# Altium schematic sheet Sheet2.SchDoc
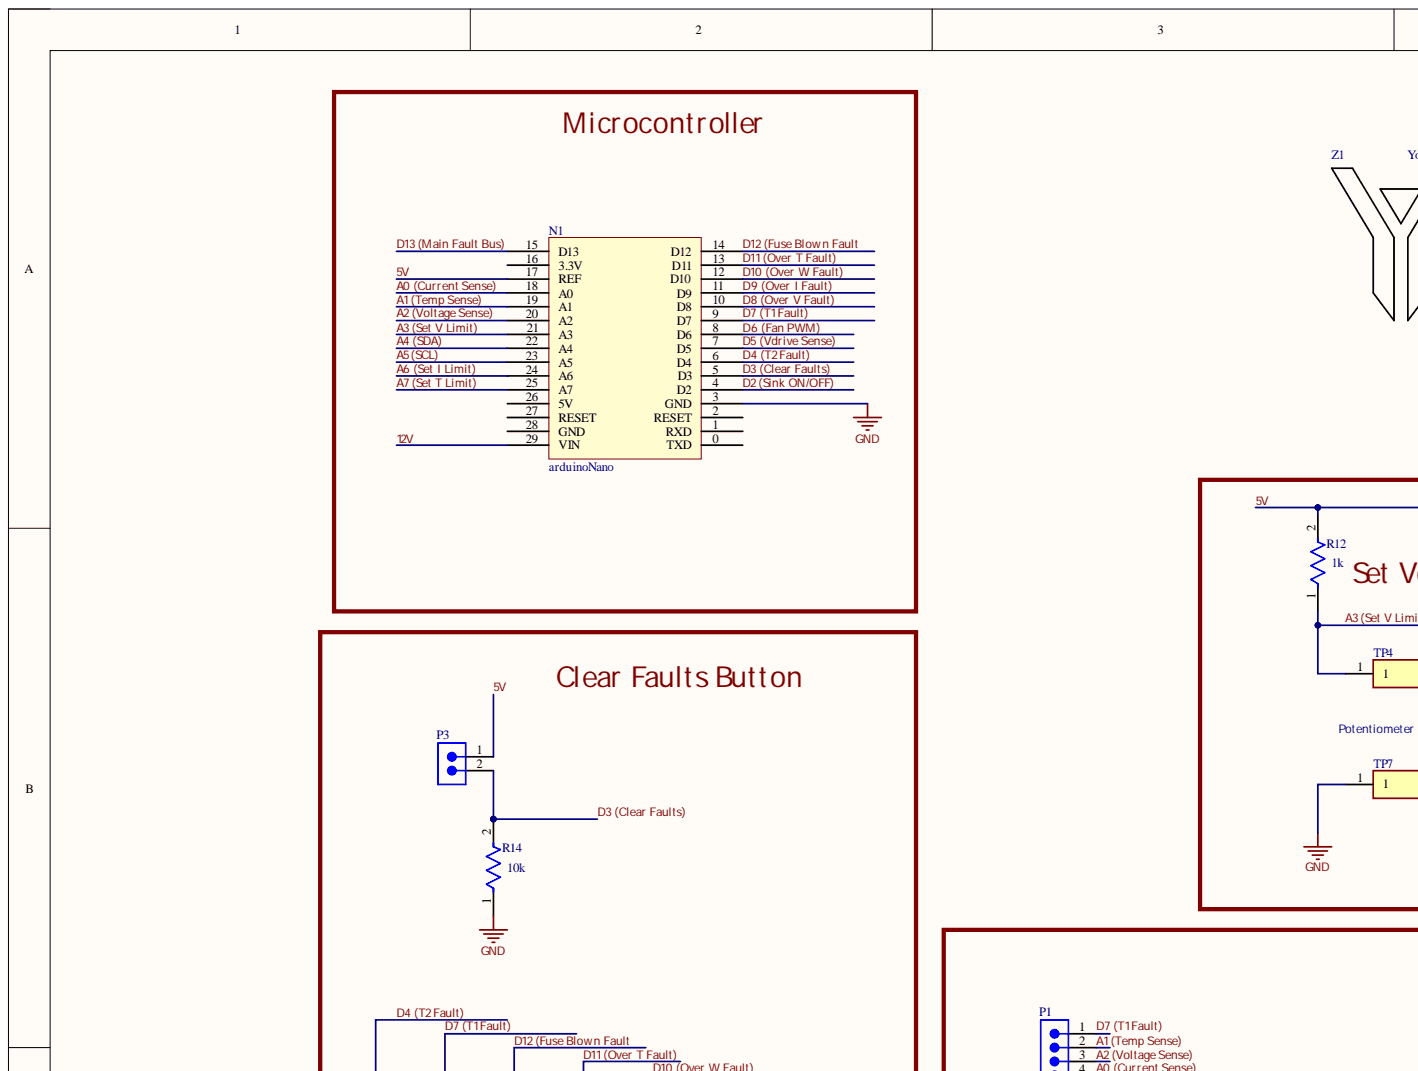
[diagram p0: Sheet2.SchDoc, top left]
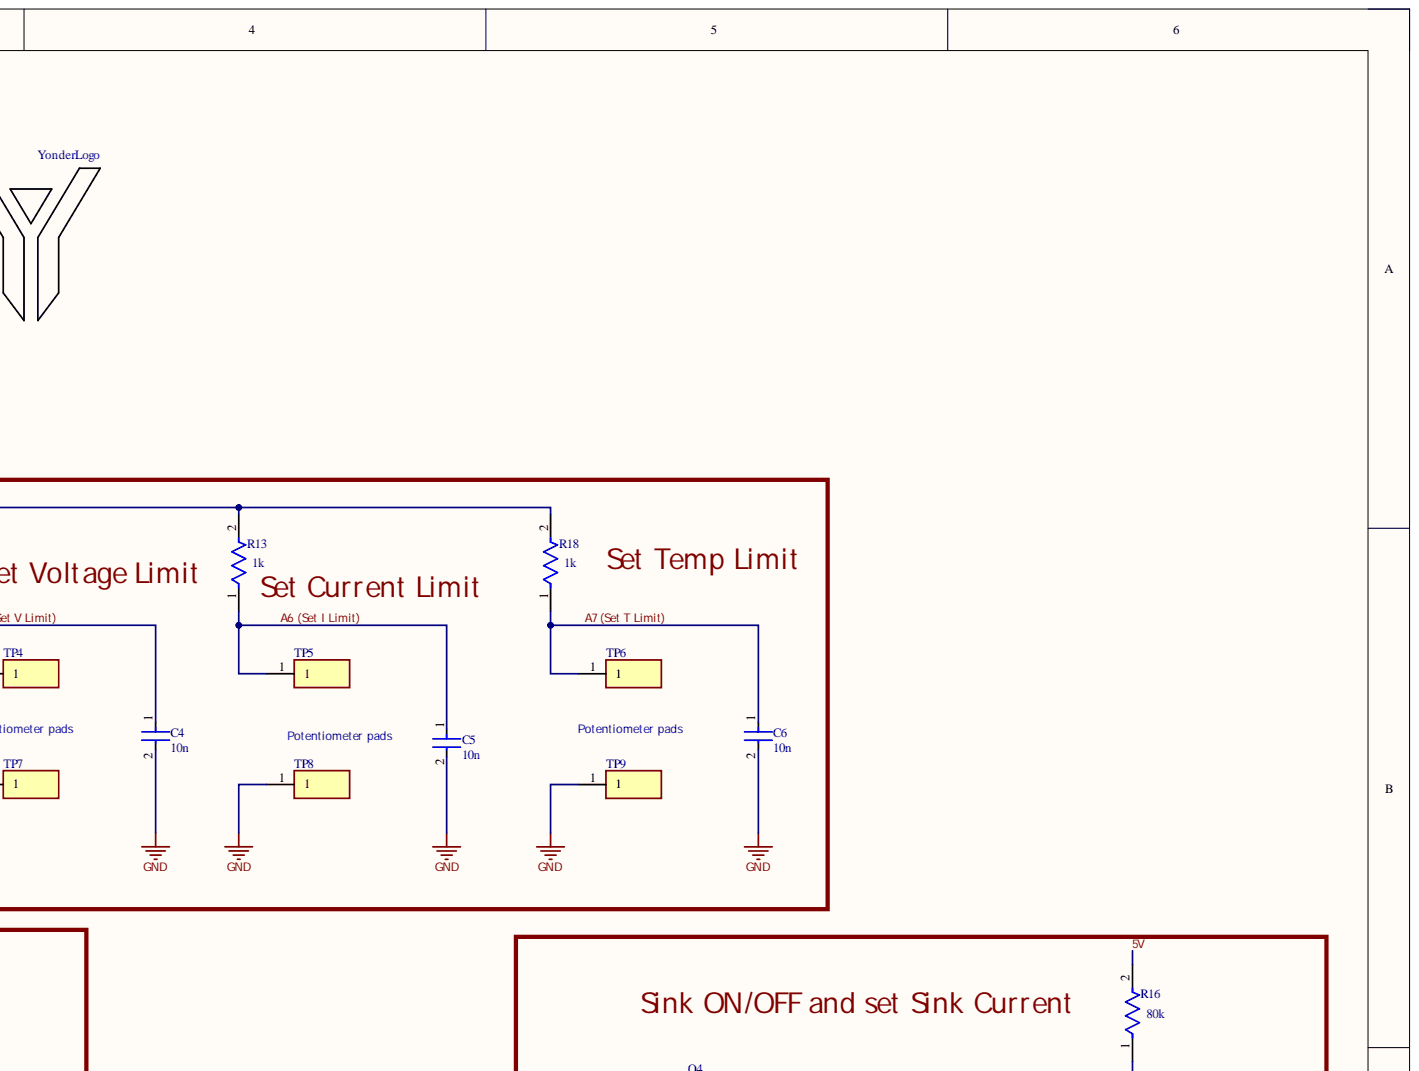
[diagram p1: Sheet2.SchDoc, top right]
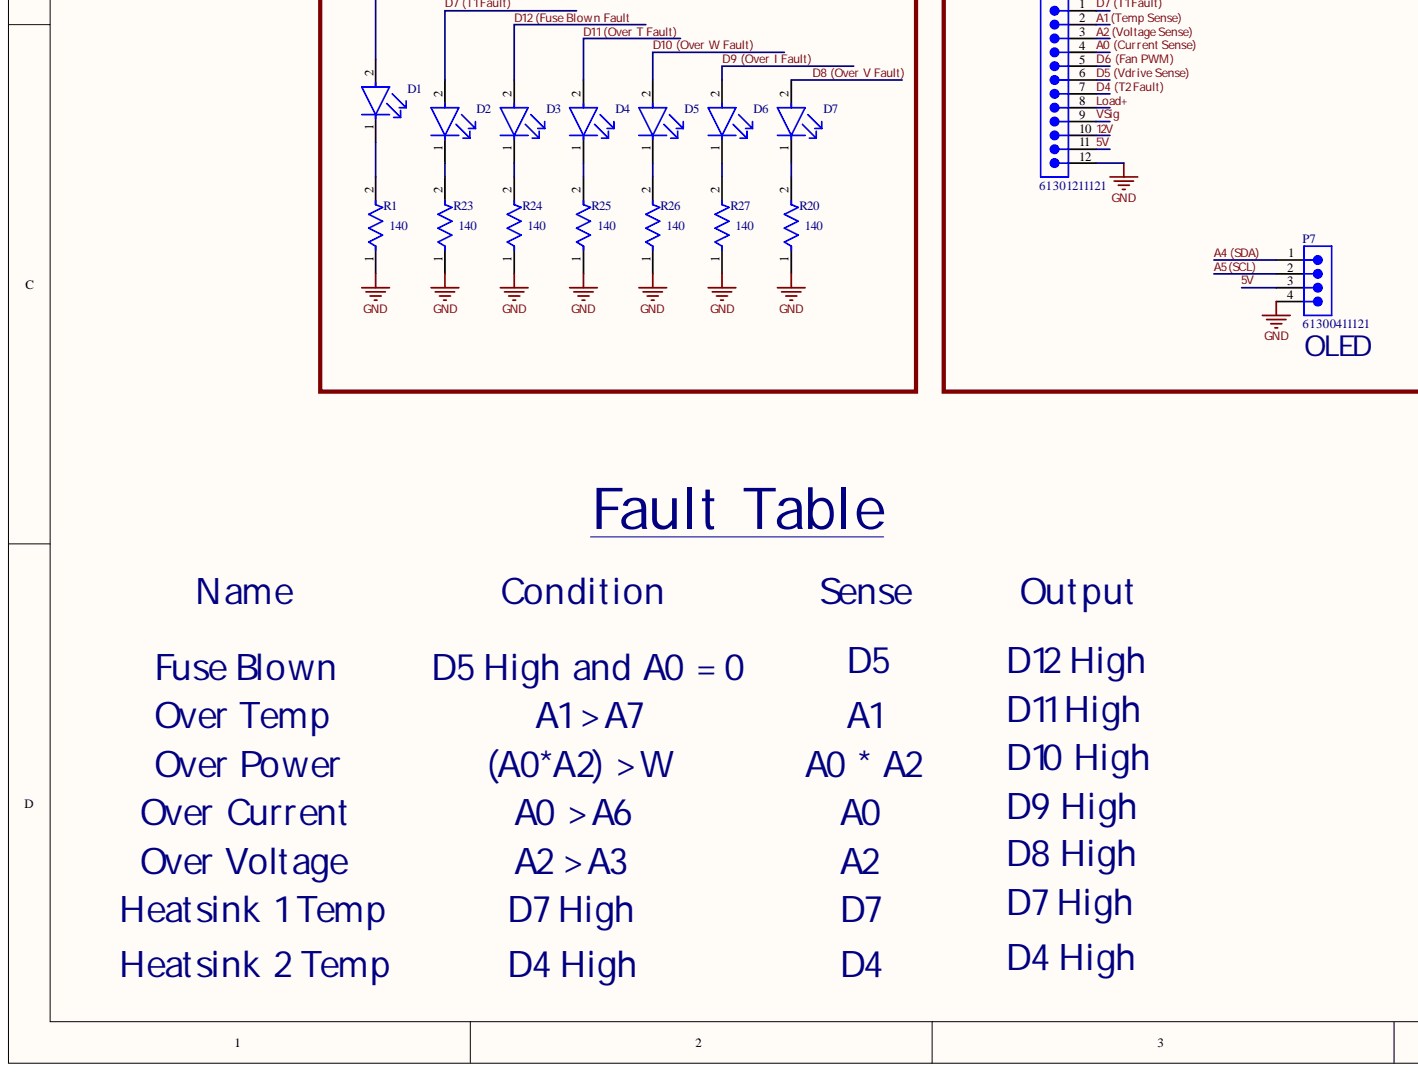
[diagram p2: Sheet2.SchDoc, bottom left]
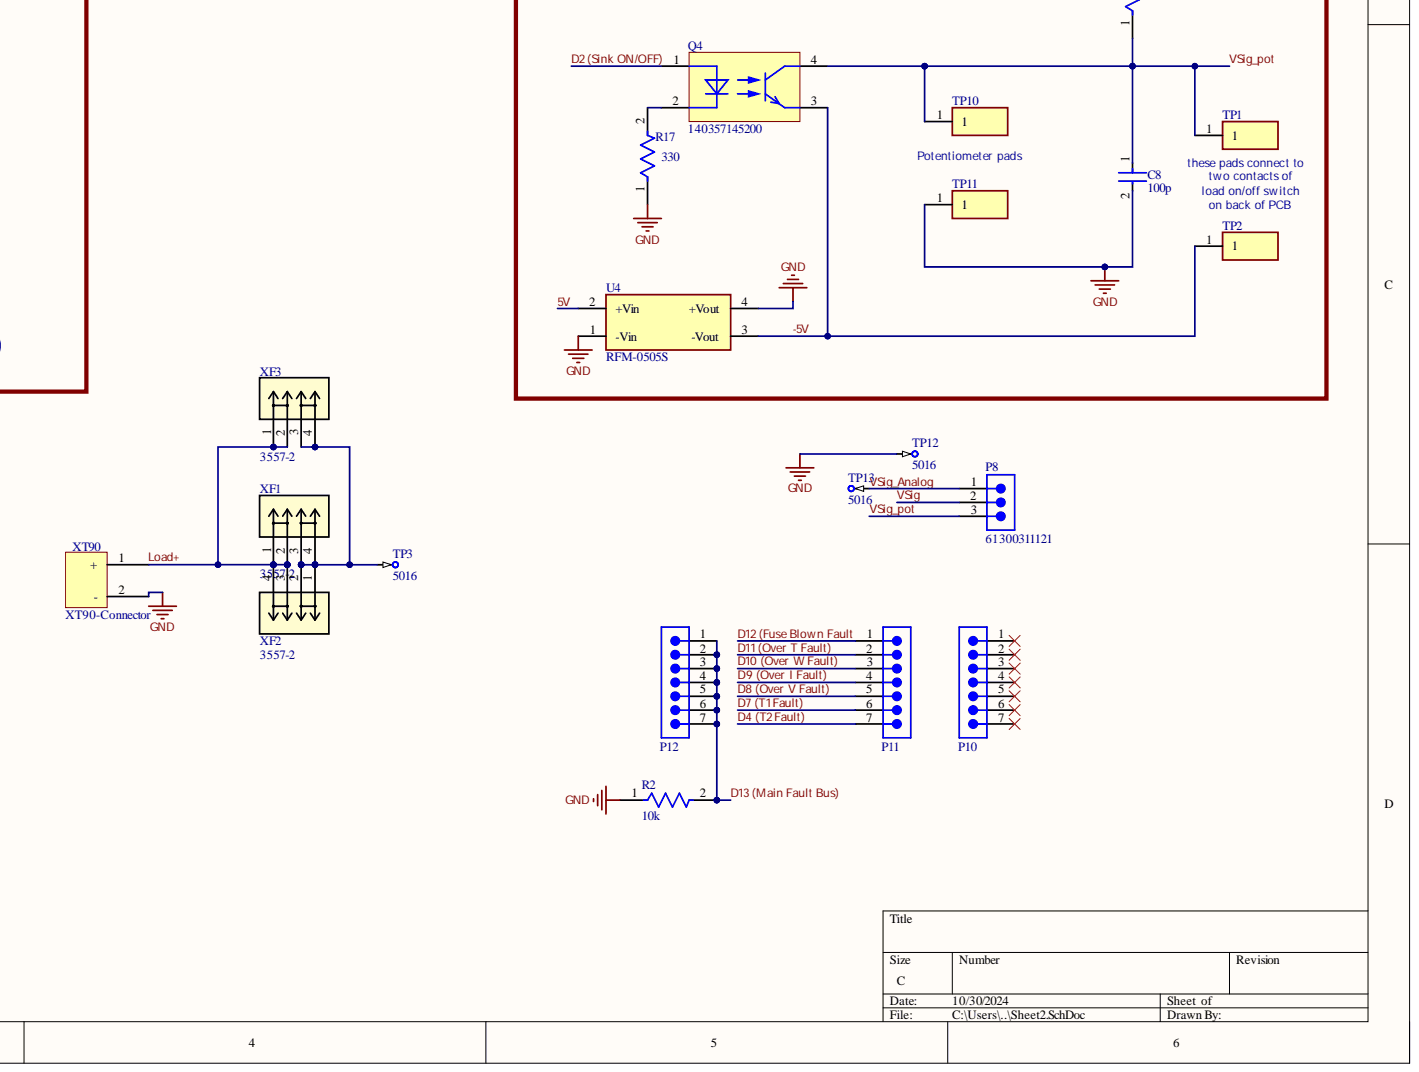
[diagram p3: Sheet2.SchDoc, bottom right]

## Parts
*XT1  XT90-Connector  [XT90-Footprint-1]
C4  10n  [FP-C1206C-EB-MFG]
C5  10n  [FP-C1206C-EB-MFG]
C6  10n  [FP-C1206C-EB-MFG]
C8  100p  [FP-C1206C-EB-MFG]
D1  LTST-C190EKT  [FP-LTST-C190EKT-MFG]  (value not drawn)
D2  LTST-C190EKT  [FP-LTST-C190EKT-MFG]  (value not drawn)
D3  LTST-C190EKT  [FP-LTST-C190EKT-MFG]  (value not drawn)
D4  LTST-C190EKT  [FP-LTST-C190EKT-MFG]  (value not drawn)
D5  LTST-C190EKT  [FP-LTST-C190EKT-MFG]  (value not drawn)
D6  LTST-C190EKT  [FP-LTST-C190EKT-MFG]  (value not drawn)
D7  LTST-C190EKT  [FP-LTST-C190EKT-MFG]  (value not drawn)
N1  arduinoNano  [Arduino nano]
P1  61301211121  [61301211121]
P3  61300211121  [61300211121]  (value not drawn)
P7  61300411121  [61300411121]
P8  61300311121  [61300311121]
P10  61300711121  [61300711121]  (value not drawn)
P11  61300711121  [61300711121]  (value not drawn)
P12  61300711121  [61300711121]  (value not drawn)
Q4  140357145200  [WL-OCPT_SOP4]
R1  140  [FP-RC1206-MFG]
R2  10k  [FP-RC1206-MFG]
R12  1k  [FP-RC1206-MFG]
R13  1k  [FP-RC1206-MFG]
R14  10k  [FP-RC1206-MFG]
R16  80k  [FP-RC1206-MFG]
R17  330  [FP-RC1206-MFG]
R18  1k  [FP-RC1206-MFG]
R20  140  [FP-RC1206-MFG]
R23  140  [FP-RC1206-MFG]
R24  140  [FP-RC1206-MFG]
R25  140  [FP-RC1206-MFG]
R26  140  [FP-RC1206-MFG]
R27  140  [FP-RC1206-MFG]
TP1  RCT-0C  [RCT-0C]  (value not drawn)
TP2  RCT-0C  [RCT-0C]  (value not drawn)
TP3  5016  [KEYSTONE-5016_V]
TP4  RCT-0C  [RCT-0C]  (value not drawn)
TP5  RCT-0C  [RCT-0C]  (value not drawn)
TP6  RCT-0C  [RCT-0C]  (value not drawn)
TP7  RCT-0C  [RCT-0C]  (value not drawn)
TP8  RCT-0C  [RCT-0C]  (value not drawn)
TP9  RCT-0C  [RCT-0C]  (value not drawn)
TP10  RCT-0C  [RCT-0C]  (value not drawn)
TP11  RCT-0C  [RCT-0C]  (value not drawn)
TP12  5016  [KEYSTONE-5016_V]
TP13  5016  [KEYSTONE-5016_V]
U4  RFM-0505S  Max Output Voltage: 5V  [FP-RFM-0505S-MFG]
XF1  3557-2  [CONN_3557-2_KEY]
XF2  3557-2  [CONN_3557-2_KEY]
XF3  3557-2  [CONN_3557-2_KEY]
Z1  YonderLogo  [PCBLogo500mil]

## Nets
GND: *XT1.2 C4.2 C5.2 C6.2 C8.2 N1.3(GND) P1.12 P7.4 R1.1 R2.1 R14.1 R17.1 R20.1 R23.1 R24.1 R25.1 R26.1 R27.1 TP7.1 TP8.1 TP9.1 TP11.1 TP12.1 U4.1(-Vin) U4.4(+Vout)
5V: N1.17(REF) P1.11 P3.1 P7.3 R12.2 R13.2 R16.2 R18.2 U4.2(+Vin)
12V: N1.29(VIN) P1.10
-5V: Q4.3 TP2.1 U4.3(-Vout)
A0 (Current Sense): N1.18(A0) P1.4
A1 (Temp Sense): N1.19(A1) P1.2
A2 (Voltage Sense): N1.20(A2) P1.3
A3 (Set V Limit): C4.1 N1.21(A3) R12.1 TP4.1
A4 (SDA): N1.22(A4) P7.1
A5 (SCL): N1.23(A5) P7.2
A6 (Set I Limit): C5.1 N1.24(A6) R13.1 TP5.1
A7 (Set T Limit): C6.1 N1.25(A7) R18.1 TP6.1
D2 (Sink ON/OFF): N1.4(D2) Q4.1
D3 (Clear Faults): N1.5(D3) P3.2 R14.2
D4 (T2 Fault): D1.2 N1.6(D4) P1.7 P11.7
D5 (Vdrive Sense): N1.7(D5) P1.6
D6 (Fan PWM): N1.8(D6) P1.5
D7 (T1 Fault): D2.2 N1.9(D7) P1.1 P11.6
D8 (Over V Fault): D7.2 N1.10(D8) P11.5
D9 (Over I Fault): D6.2 N1.11(D9) P11.4
D10 (Over W Fault): D5.2 N1.12(D10) P11.3
D11 (Over T Fault): D4.2 N1.13(D11) P11.2
D12 (Fuse Blown Fault: D3.2 N1.14(D12) P11.1
D13 (Main Fault Bus): N1.15(D13) P12.1 P12.2 P12.3 P12.4 P12.5 P12.6 P12.7 R2.2
Load+: *XT1.1 P1.8 XF1.1 XF1.2 XF2.3 XF2.4 XF3.1 XF3.2
VSig: P1.9 P8.2
VSig_Analog: P8.1 TP13.1
VSig_pot: C8.1 P8.3 Q4.4 R16.1 TP1.1 TP10.1
NetD1_1: D1.1 R1.2
NetD2_1: D2.1 R23.2
NetD3_1: D3.1 R24.2
NetD4_1: D4.1 R25.2
NetD5_1: D5.1 R26.2
NetD6_1: D6.1 R27.2
NetD7_1: D7.1 R20.2
NetQ4_2: Q4.2 R17.2
NetTP3_1: TP3.1 XF1.3 XF1.4 XF2.1 XF2.2 XF3.3 XF3.4
Clear Faults Button:
Microcontroller:
Set Current Limit:
Set Temp Limit:
Set Voltage Limit:
Sink ON/OFF and set Sink Current:

Not connected: N1 0(TXD) 1(RXD) 2(RESET) 16(3.3V) 26(5V) 27(RESET) 28(GND); P10 1 2 3 4 5 6 7

## Library data of the parts
*XT1 = XT90-2: XT90 connector for vertical PCB mounting; Component Kind: Standard; Library Name: Yonder Dynamics
C4-C6 C8 = CMP-03020-000153-1: CAP CER 0.1UF 100V X7R 1206; Manufacturer: KEMET; Manufacturer Part Number: C1206C104K1RACTU; Automotive Grade: Grade 0; Capacitance: 0.10 μF; Material: Ceramic; Temperature Coefficient: 15%; Tolerance: 0.1; Value: 0.10 μF; Automotive: Yes; Category: Cap; Component Kind: Standard; ComponentLink2Description: Datasheet; ComponentLink2URL: https://content.kemet.com/datasheets/KEM_C1007_X8R_ULTRA_150C_SMD.pdf; Device Class L1: Passive Components; Device Class L2: Capacitors; Device Class L3: Ceramic Capacitors; Digikey Description: CAP CER 0.1UF 100V X7R 1206; Height: 0.88mm; Ipc Land Pattern Name: CAPC320160X78; Lead Free: Yes; Library Name: Altium Content Vault; Mouser Description: CAP CER 0.1UF 100V X7R 1206; Octopart: https://octopart.com/c1206c104k1ractu-kemet-89521; Package: C1206C-EB; RoHS: TRUE; Temperature: -55°C to +150°C; Temperature Characteristic: X7R; Temperature Range High: +150°C; Temperature Range Low: -55°C; Voltage Rating: 100 V
D1-D7 = CMP-22017-000021-1: LED RED CLEAR CHIP SMD; Dominant Wavelength: 621nm; Forward Current: 30mA; Forward Voltage: 2V; Lens Color: Colorless; Luminous Intensity: 2mcd; Max Power Dissipation: 100mW; Number of Elements: 1; Reverse Voltage: 5V; Test Current: 10mA; Viewing Angle: 130°; Wavelength: 630nm; Case Code (Imperial): 0603; Case Code (Metric): 1608; Case/Package: 0603; Color: Red; Component Kind: Standard; Depth: 0.8mm; Height: 0.8mm; Illumination Color: Red; Lead Free: Lead Free; Length: 1.6mm; Lens Style: Clear; Lens Transparency: Clear; Library Name: Altium Content Vault; Max Operating Temperature: 85°C; Min Operating Temperature: -55°C; Mount: Surface Mount; Number of LEDs: 1; Number of Pins: 2; Package Quantity: 1; Packaging: Tape and Reel; Power Dissipation: 100mW; Power Rating: 100mW; Radiation Hardening: No; Width: 0.8mm
N1 = CMP-004-000003-2: Component Kind: Standard; Design Item ID: arduinoNano; Library Name: Yonder Dynamics - 2022-2023; Source: PanographBoard.SchLib
P1 = CMP-1502-01086-3: NexarPartId: 32855538; Connector Type: Male Pin; Contact Resistance: 20mΩ; Current Rating: 3A; Gender: Male; Number of Contacts: 12; Operating Supply Voltage: 250V; Pitch: 2.54mm; Color: Black; Component Kind: Standard; Contact Material: Copper; Contact Mating Length: 5.9944mm; Contact Plating: Gold; Lead Pitch: 2.54mm; Library Name: Altium Content Vault; Mount: Through Hole; Number of Pins: 12; Number of Positions: 12; Number of Rows: 1; Orientation: Vertical; Packaging: Bulk; REACH SVHC: Unknown; RoHS: Compliant; Termination: Solder
P3 = CMP-1712-00002-6: NexarPartId: 20081794; Connector Type: Male Pin; Contact Resistance: 20mΩ; Current Rating: 3A; Gender: Male; Number of Contacts: 2; Operating Supply Voltage: 250V; Pitch: 2.54mm; Color: Black; Component Kind: Standard; Contact Gender: Male; Contact Material: Copper; Contact Mating Length: 5.9944mm; Contact Plating: Gold; Height: 8.94mm; Housing Material: PBT; Lead Free: Lead Free; Lead Pitch: 2.54mm; Library Name: Altium Content Vault; Max Operating Temperature: 125°C; Min Operating Temperature: -40°C; Mount: Through Hole; Number of Pins: 2; Number of Positions: 2; Number of Rows: 1; Orientation: Vertical; Packaging: Bulk; REACH SVHC: No SVHC; RoHS: Compliant; Termination: Through Hole; Voltage Rating: 250V
P7 = CMP-1502-01064-3: NexarPartId: 32855522; Connector Type: Male Pin; Contact Resistance: 20mΩ; Current Rating: 3A; Gender: Male; Number of Contacts: 4; Operating Supply Voltage: 250V; Pitch: 2.54mm; Color: Black; Component Kind: Standard; Contact Material: Copper; Contact Mating Length: 5.9944mm; Contact Plating: Gold; Height: 8.89mm; Lead Free: Lead Free; Lead Pitch: 2.54mm; Library Name: Altium Content Vault; Max Operating Temperature: 105°C; Min Operating Temperature: -40°C; Mount: Through Hole; Number of Pins: 4; Number of Positions: 4; Number of Rows: 1; Orientation: Vertical; Packaging: Bulk; REACH SVHC: Unknown; RoHS: Compliant; Termination: Solder; Voltage Rating: 250V
P8 = CMP-1502-01062-3: NexarPartId: 32855521; Connector Type: Male Pin; Contact Resistance: 20mΩ; Current Rating: 3A; Gender: Male; Number of Contacts: 3; Operating Supply Voltage: 250V; Pitch: 2.54mm; Color: Black; Component Kind: Standard; Contact Material: Copper; Contact Mating Length: 5.9944mm; Contact Plating: Gold; Height: 8.94mm; Lead Free: Lead Free; Lead Pitch: 2.54mm; Library Name: Altium Content Vault; Max Operating Temperature: 105°C; Min Operating Temperature: -40°C; Mount: Through Hole; Number of Pins: 3; Number of Positions: 3; Number of Rows: 1; Orientation: Vertical; Packaging: Bulk; REACH SVHC: Unknown; RoHS: Compliant; Termination: Solder; Voltage Rating: 250V
P10-P12 = CMP-1502-01074-3: NexarPartId: 32855531; Connector Type: Male Pin; Contact Resistance: 20mΩ; Current Rating: 3A; Gender: Male; Number of Contacts: 7; Operating Supply Voltage: 250V; Pitch: 2.54mm; Color: Black; Component Kind: Standard; Contact Material: Copper; Contact Mating Length: 5.9944mm; Contact Plating: Gold; Lead Pitch: 2.54mm; Library Name: Altium Content Vault; Mount: Through Hole; Number of Pins: 7; Number of Positions: 7; Number of Rows: 1; Orientation: Vertical; Packaging: Bulk; REACH SVHC: Unknown; RoHS: Compliant; Termination: Solder
Q4 = CMP-03913-114060673-1: 140357145200 Optocoupler, Transistor, 35V, Sop-4 Rohs Compliant: Yes; Forward Current: 60mA; Forward Voltage: 1.24V; Isolation Voltage: 3.75kV; Max Reverse Voltage (DC): 6V; Component Kind: Standard; Height: 2mm; Length: 4.4mm; Library Name: Altium Content Vault; Max Operating Temperature: 110°C; Min Operating Temperature: -55°C; NexarPartId: 114060673; RoHS: Compliant; Width: 3.6mm
R1 R2 R12-R14 R16-R18 R20 R23-R27 = CMP-2100-03681-2: RES SMD 0 OHM JUMPER 1/4W 1206; Manufacturer: YAGEO; Manufacturer Part Number: RC1206JR-070RL; Power Rating: 0.25W; Resistance: 0Ω; Temperature Coefficient: 300ppm/°C; Tolerance: 5%; Value: 0Ω; Voltage Rating: 200V; Automotive: No; Category: Res; Component Kind: Standard; ComponentLink2Description: Datasheet; ComponentLink2URL: https://www.yageo.com/upload/media/product/productsearch/datasheet/rchip/PYu-RC_Group_51_RoHS_L_10.pdf; Composition: Thick Film; Device Class L1: Passive Components; Device Class L2: Resistors; Device Class L3: Chip SMD Resistors; Digikey Description: RES SMD 0 OHM JUMPER 1/4W 1206; Footprint Url: https://www.yageo.com/upload/website/yageo_PYu-R_Mount_10_19050818_343.pdf; Height: 0.65mm; Ipc Land Pattern Name: RESC310160X55; Lead Free: Yes; Library Name: Altium Content Vault; Mouser Description: Resistor: thick film; SMD; 1206; 0O; 0.25W; ±5%; -55÷155°C; Octopart: https://octopart.com/rc1206jr-070rl-yageo-40025601; Package: RC1206; RoHS: TRUE; Series: RC; Temperature: -55°C to +155°C; Temperature Range High: +155°C; Temperature Range Low: -55°C
TP1 TP2 TP4-TP11 = RCT-0C: Test Point; Manufacturer_Name: TE Connectivity; Arrow Part Number: RCT-0C; Arrow Price/Stock: https://www.arrow.com/en/products/rct-0c/te-connectivity?region=nac; Component Kind: Standard; Datasheet Link: https://www.te.com/commerce/DocumentDelivery/DDEController?Action=srchrtrv&DocNm=1773266&DocType=Data%20Sheet&DocLang=English&PartCntxt=1625854-2&DocFormat=pdf; Manufacturer_Part_Number: RCT-0C; Mouser Part Number: 279-RCT-0C; Mouser Price/Stock: https://www.mouser.co.uk/ProductDetail/TE-Connectivity-Holsworthy/RCT-0C?qs=ip69W3eHERWzZOsRKmFYAw%3D%3D
TP3 TP12 TP13 = CMP-2000-05178-1: Test Point, 1-Pin SMT, RoHS, Tape and Reel; Manufacturer: Keystone; Catalog Drawings: 5016Top    5016Side    5016Front; Featured Product: SurfaceMountTestPoints    5019MiniatureSurfaceMountTestPoint; Online Catalog: SurfaceMountTestPoints; Other Names: 5016KDKR; Category: TestandMeasurement; Component Kind: Standard; Datasheet URL: https://ciiva.com/datasheet-click-2917613?src=ciiva&sig=4a4e90f; Datasheet Version: Rev. D, 03/2014; Family: TestPoints; Library Name: Altium Content Vault; Manufacturer URL: http://www.keyelco.com/; Material: PhosphorBronze; Mounting Type: SurfaceMount; Package Description: 1-Pin Test Point, Body 3.81 x 2.04 mm; Package Reference: 5016; Packaging: Digi-Reel; RoHS Information: RoHSComplianceStatement; Standard Package: 1; Terminal: 0.015"(0.38mm)PhosphorBronze,SilverPlated; Type: PCTestPoint,Compact
U4 = CMP-29842-000017-1: DC DC CONVERTER 5V 1W; Component Kind: Standard; Height: 10mm; Length: 11.5mm; Library Name: Altium Content Vault; Weight: 1.4g; Width: 6mm
XF1-XF3 = CMP-018-000000-2: No Description Available; Mfr_Name: Keystone Electronics; Component Kind: Standard; Design Item ID: 3557-2; Digi-Key_Part_Number_1: 3557-2K-ND; Digi-Key_Part_Number_2: 36-3557-2-ND; Digi-Key_Part_Number_3: 36-3557-2-NDL; Manufacturer_Part_Number: 3557-2; RefDes: RefDes; Source: 3557-2.SchLib; Type: DEV; Copyright: Copyright (C) 2022 Ultra Librarian. All rights reserved.; Library Name: Yonder Dynamics - 2022-2023
Z1 = CMP-005-000005-1: Component Kind: Standard; Design Item ID: YonderLogo; Library Name: Yonder Dynamics; Source: MainEnclosure.SchLib
